# Altium schematic sheet UART_USB.SchDoc
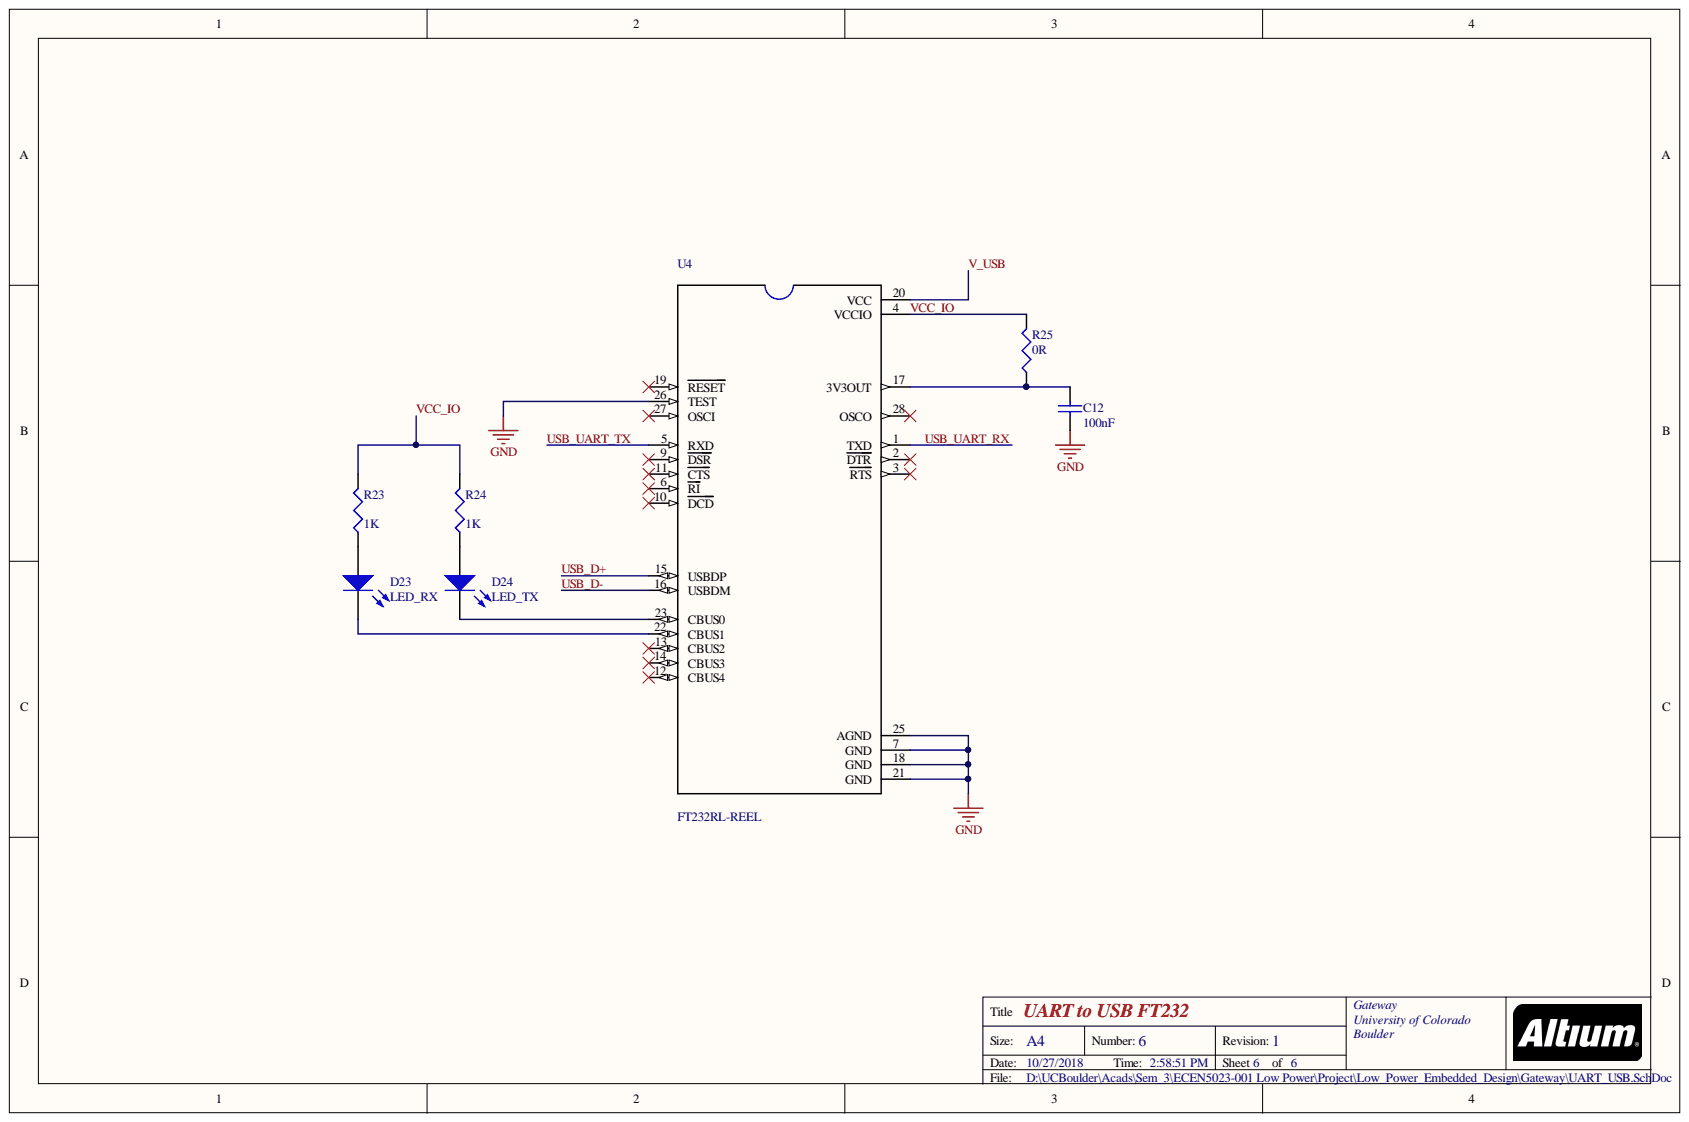
[diagram p0: UART_USB.SchDoc]

## Parts
C12  value 100nF  [1608[0603]]  (hidden comment: 0603YC104MAT4A)
D23  LED_RX  [3.2X1.6X1.1]
D24  LED_TX  [3.2X1.6X1.1]
R23  value 1K  [1608[0603]]  (hidden comment: MCT06030C1001FP500)
R24  value 1K  [1608[0603]]  (hidden comment: MCT06030C1001FP500)
R25  value 0R  [1608[0603]]  (hidden comment: MCT0603MZ0000ZP500)
U4  FT232RL-REEL  [SOP65P780X200-28N]

## Nets
GND: C12.2 U4.7(GND) U4.18(GND) U4.21(GND) U4.25(AGND) U4.26(TEST)
USB_D+: U4.15(USBDP)
USB_D-: U4.16(USBDM)
USB_UART_RX: U4.1(TXD)
USB_UART_TX: U4.5(RXD)
V_USB: U4.20(VCC)
VCC_IO: R23.2 R24.2 R25.2 U4.4(VCCIO)
NetC12_1: C12.1 R25.1 U4.17(3V3OUT)
NetD23_1: D23.1 R23.1
NetD23_2: D23.2 U4.22(CBUS1)
NetD24_1: D24.1 R24.1
NetD24_2: D24.2 U4.23(CBUS0)

Not connected: U4 2(DTR) 3(RTS) 6(RI) 9(DSR) 10(DCD) 11(CTS) 12(CBUS4) 13(CBUS2) 14(CBUS3) 19(RESET) 27(OSCI) 28(OSCO)

## Library data of the parts
C12 = Cap: CAP CER 0.1UF 16V X7R 0603; Manufacturer: AVX Corporation; Component Kind: Standard; ComponentLink1Description: Digi-Key Link; ComponentLink1URL: https://www.digikey.com/products/en/capacitors/ceramic-capacitors/60?k=capacitor&k=&pkeyword=capacitor&pv2049=u0.1%C2%B5F&sf=0&FV=c0005%2C1c0002%2C380009%2C400005%2C1f140000%2Cffe0003c&quantity=&ColumnSort=0&page=1&stock=1&pageSize=25; Digi-Key Part Number: 478-7617-1-ND; LatestRevisionDate: 17-Jul-2002; LatestRevisionNote: Re-released for DXP Platform.; Library Name: Miscellaneous Devices.IntLib; Manufacturer Part Number: 0603YC104MAT4A; PackageDescription: Radial Cap, Thru-Hole; 2 Leads; 0.3 in Pin Spacing; PackageReference: RAD-0.3; Published: 8-Jun-2000; Signal Integrity: Cap; Simulation: CAP; Publisher: Altium Limited
D23 = LED2: LED RED DIFFUSED 0603 SMD; Manufacturer: OSRAM Opto Semiconductors Inc.; Manufacturer Part Number: LS L29K-H1J2-1-Z; Component Kind: Standard; ComponentLink1Description: Digi-Key Link; ComponentLink1URL: https://www.digikey.com/product-detail/en/osram-opto-semiconductors-inc/LG-L29K-F2J1-24-Z/475-3118-1-ND/4899549; Digi-Key Part Number: 475-1195-1-ND; Library Name: Miscellaneous Devices.IntLib; Simulation: LED2
D24 = LED2: LED YELLOW DIFFUSED 0603 SMD; Manufacturer: OSRAM Opto Semiconductors Inc.; Manufacturer Part Number: LY L29K-H1K2-26-Z; Component Kind: Standard; ComponentLink1Description: Digi-Key Link; ComponentLink1URL: https://www.digikey.com/product-detail/en/osram-opto-semiconductors-inc/LY-L29K-H1K2-26-Z/475-1196-1-ND/810357; Digi-Key Part Number: 475-1196-1-ND; Library Name: Miscellaneous Devices.IntLib; Simulation: LED2
R23 R24 = Res3: RES SMD 1K OHM 1% 1/8W 0603; Manufacturer: Vishay Beyschlag; Component Kind: Standard; ComponentLink1Description: Digi-Key URL; ComponentLink1URL: https://www.digikey.com/product-detail/en/vishay-beyschlag/MCT06030C1001FP500/MCT0603-1.00K-CFCT-ND/2607875; Digi-Key Part Number: MCT0603-1.00K-CFCT-ND; Library Name: Miscellaneous Devices.IntLib; Manufacturer Part Number: MCT06030C1001FP500; Signal Integrity: Res; Simulation: RESISTOR
R25 = Res3: RES 0 OHM JUMPER 1/8W 0603; Manufacturer: Vishay Beyschlag; Component Kind: Standard; ComponentLink1Description: Digi-Key Link; ComponentLink1URL: https://www.digikey.com/product-detail/en/vishay-beyschlag/MCT0603MZ0000ZP500/749-1745-1-ND/7348053; Digi-Key Part Number: 749-1745-1-ND; Library Name: Miscellaneous Devices.IntLib; Manufacturer Part Number: MCT0603MZ0000ZP500; Signal Integrity: Res; Simulation: RESISTOR
U4 = FT232RL-REEL: USB Bridge, USB to UART USB 2.0 UART Interface 28-SSOP; Manufacturer: FTDI, Future Technology Devices International Ltd; MF: FTDI,; Availability: Available; Component Kind: Standard; ComponentLink1Description: Digi-Key Link; ComponentLink1URL: https://www.digikey.com/product-detail/en/ftdi-future-technology-devices-international-ltd/FT232RL-REEL/768-1007-1-ND/1836402; Digi-Key Part Number: 768-1007-1-ND; Library Name: FT232RL-REEL.IntLib; Manufacturer Part Number: FT232RL-REEL; MP: FT232RL-REEL; Package: SSOP-28 FTDI; Price: None; SnapEDA_Link: https://www.snapeda.com/parts/FT232RL-REEL/FTDI%2C%20Future%20Technology%20Devices%20International%20Ltd/view-part/?ref=snap
